# Altium schematic sheet Sheet1.SchDoc
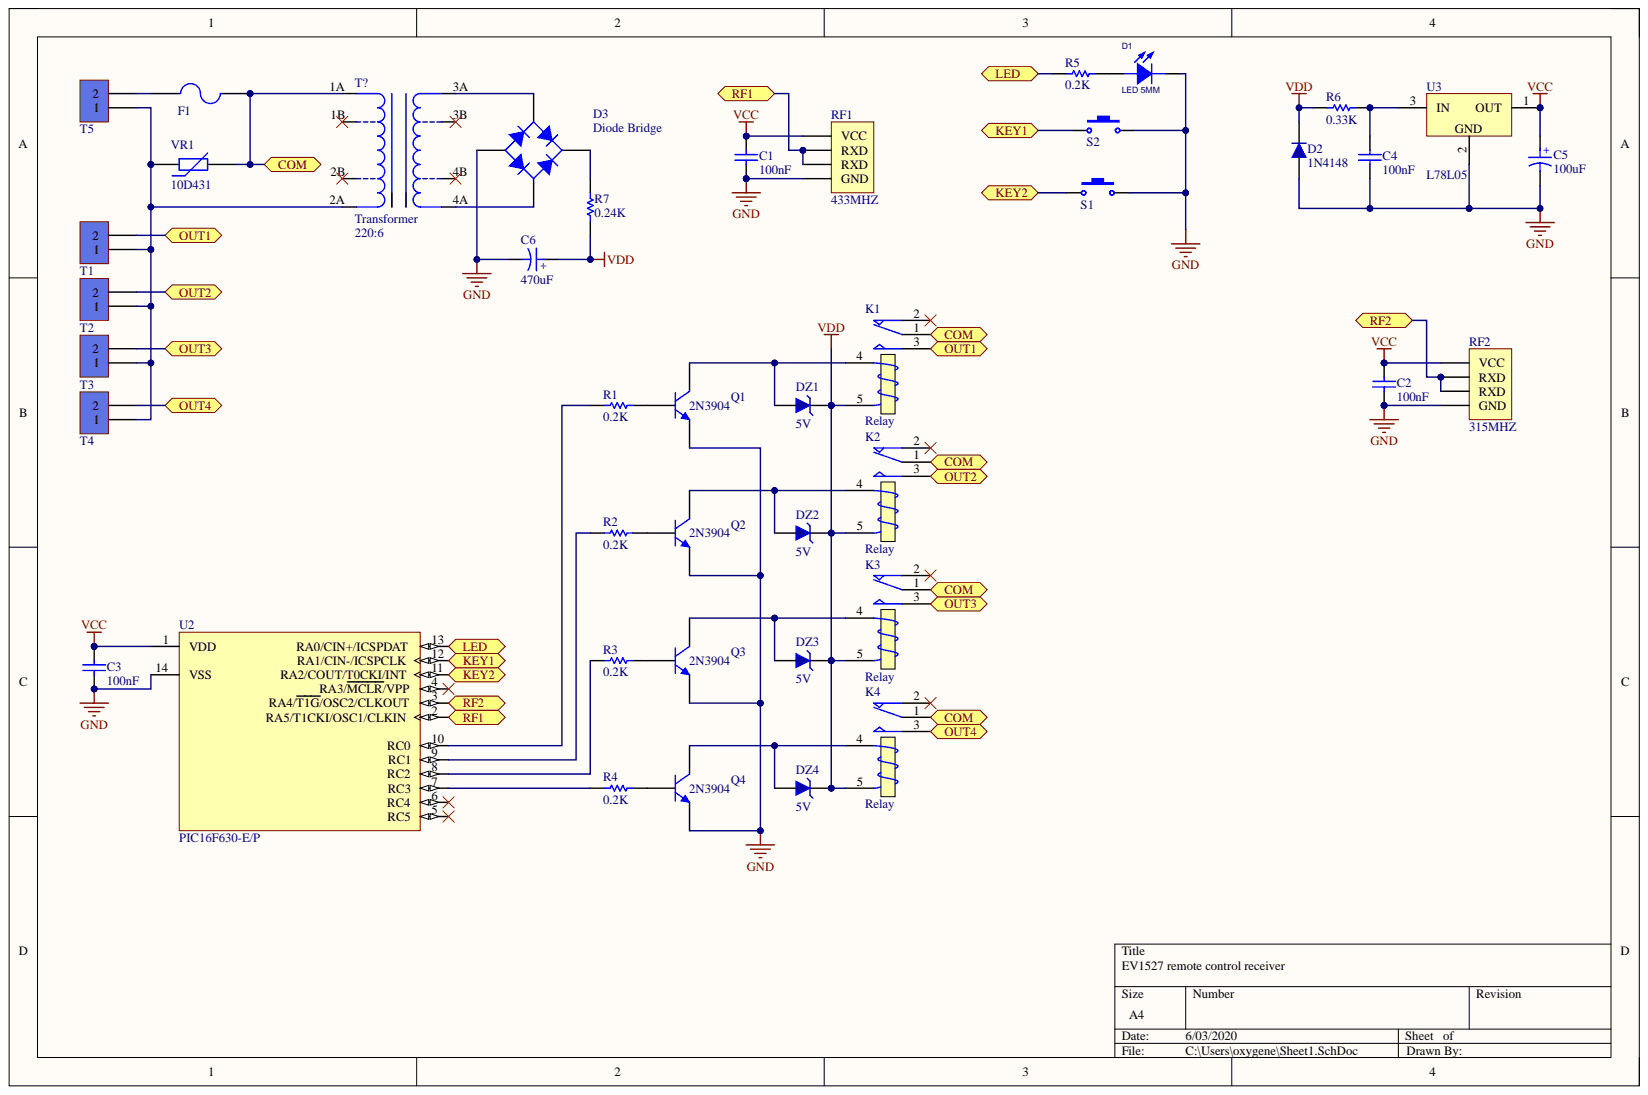
[diagram p0: Sheet1.SchDoc]

## Parts
C1  100nF  [Cap-100nF]
C2  100nF  [Cap-100nF]
C3  100nF  [Cap-100nF]
C4  100nF  [Cap-100nF]
C5  100uF  [Cap electrolitic radial 8x14-3.5]
C6  470uF  [Cap electrolitic radial 8x12-3.5]
D1  LED 5MM  [LED5MM_BLUE]
D2  1N4148  [DO 35]
D3  Diode Bridge  [Bridge WOB PACKAGE]
DZ1  5V  [DO 35]
DZ2  5V  [DO 35]
DZ3  5V  [DO 35]
DZ4  5V  [DO 35]
F1  F=1.0 A  [Fuse 5x20 With holder pin]  (value not drawn)
K1  Relay  [Relay 15.40x12.10x13.10]
K2  Relay  [Relay 15.40x12.10x13.10]
K3  Relay  [Relay 15.40x12.10x13.10]
K4  Relay  [Relay 15.40x12.10x13.10]
Q1  2N3904  [2N3904]
Q2  2N3904  [2N3904]
Q3  2N3904  [2N3904]
Q4  2N3904  [2N3904]
R1  0.2K  [RES-(1/4)]
R2  0.2K  [RES-(1/4)]
R3  0.2K  [RES-(1/4)]
R4  0.2K  [RES-(1/4)]
R5  0.2K  [RES-(1/4)]
R6  0.33K  [RES-(1/4)]
R7  0.24K  [RES-(1/4)-Stand]
RF1  433MHZ  [HDR1X4]
RF2  315MHZ  [HDR1X4]
S1  Switch PB  [Switch - Push to On]  (value not drawn)
S2  Switch PB  [Switch - Push to On]  (value not drawn)
T1  KF301_Terminal  [KF301 2PIN]  (value not drawn)
T2  KF301_Terminal  [KF301 2PIN]  (value not drawn)
T3  KF301_Terminal  [KF301 2PIN]  (value not drawn)
T4  KF301_Terminal  [KF301 2PIN]  (value not drawn)
T5  KF301_Terminal  [KF301 2PIN]  (value not drawn)
T?  Transformer  Voltage Ratio: 220:6  [Trans- Small]
U2  PIC16F630-E/P  [DIP14]
U3  L78L05  [78LXX]
VR1  10D431  [10D XXX]

## Ports
COM, KEY1, KEY2, LED, OUT1, OUT2, OUT3, OUT4, RF1, RF2

## Nets
GND: C1.1 C2.1 C3.1 C4.1 C5.2 C6.2 D1.2 D2.1 D3.4 Q1.1 Q2.1 Q3.1 Q4.1 RF1.4 RF2.4 S1.2 S2.2 U2.14 U3.2
VCC: C1.2 C2.2 C3.2 C5.1 RF1.1 RF2.1 U2.1 U3.1
VDD: C6.1 D2.2 DZ1.2 DZ2.2 DZ3.2 DZ4.2 K1.5 K2.5 K3.5 K4.5 R6.2 R7.1
COM: F1.2 K1.1 K2.1 K3.1 K4.1 T?.1A VR1.2 ; port COM
KEY1: S2.1 U2.12 ; port KEY1
KEY2: S1.1 U2.11 ; port KEY2
LED: R5.1 U2.13 ; port LED
OUT1: K1.3 T1.2 ; port OUT1
OUT2: K2.3 T2.2 ; port OUT2
OUT3: K3.3 T3.2 ; port OUT3
OUT4: K4.3 T4.2 ; port OUT4
RF1: RF1.2 RF1.3 U2.2 ; port RF1
RF2: RF2.2 RF2.3 U2.3 ; port RF2
NetC4_2: C4.2 R6.1 U3.3
NetD1_1: D1.1 R5.2
NetD3_1: D3.1 R7.2
NetD3_2: D3.2 T?.3A
NetD3_3: D3.3 T?.4A
NetDZ1_1: DZ1.1 K1.4 Q1.3
NetDZ2_1: DZ2.1 K2.4 Q2.3
NetDZ3_1: DZ3.1 K3.4 Q3.3
NetDZ4_1: DZ4.1 K4.4 Q4.3
NetF1_1: F1.1 T5.2
NetQ1_2: Q1.2 R1.2
NetQ2_2: Q2.2 R2.2
NetQ3_2: Q3.2 R3.2
NetQ4_2: Q4.2 R4.2
NetR1_1: R1.1 U2.10
NetR2_1: R2.1 U2.9
NetR3_1: R3.1 U2.8
NetR4_1: R4.1 U2.7
NetT1_1: T1.1 T2.1 T3.1 T4.1 T5.1 T?.2A VR1.1

Not connected: K1 2; K2 2; K3 2; K4 2; T? 1B 2B 3B 4B SA SB; U2 4 5 6

## Library data of the parts
C1-C4 = CAP CERAMIC TOTAL SIZE: CAP Ceramic Total; Designer: Ali Hafizi; Component Kind: Standard; Library Name: Altium.IntLib
C5 C6 = Cap ELECTROLITIC TOTAL SIZE: Cap electrolitic radial; Designer: Abbas Basereh; Component Kind: Standard; Data: 16-12-2016; Library Name: Altium.IntLib
  C5: VALUE: 100uF
  C6: VALUE: 470uF
D1 = LED 5MM: Light Emitting Diode; Designer: Abbas Basereh; Component Kind: Standard; Data: 16-12-2016; Library Name: Altium.IntLib
D2 = 1N4148: DIODE GEN PURP 100V 200MA DO35; Component Kind: Standard; ComponentLink1Description: Manufacturer Link; ComponentLink1URL: http://www.digikey.com/product-detail/en/fairchild-on-semiconductor/1N4148/1N4148FS-ND/458603; ComponentLink2Description: Datasheet; Library Name: Altium.IntLib; PackageDescription: DIODE GEN PURP 100V 200MA DO35; PackageReference: DO 35; PackageVersion: 2017-1-12; PART.NO: 1N4148; Published: 2017-1-12; Publisher: Abbas Basereh
D3 = Diode Bridge TOTAL SIZE: Full Wave Diode Bridge; Designer: Abbas Basereh; Component Kind: Standard; ComponentLink1Description: Datasheet; ComponentLink2Description: Reference; Design Date: 1395/11/09; Library Name: Altium.IntLib; Mounting Technology: Surface Mount\Through-Hole; Status: Unreleased
DZ1-DZ4 = ZENER GENERAL DIODE: DIODE ZENER GENERAL; Component Kind: Standard; ComponentLink1Description: Manufacturer Link; ComponentLink2Description: Datasheet; Library Name: Altium.IntLib; PackageDescription: DIODE GEN ZENER; PackageReference: DO 35; PackageVersion: 2017-1-12; Published: 2017-1-12; VALUE: 5V; Publisher: Abbas Basereh
F1 = Fuse 5x20 with holdeer pins: Fuses 5x20; Desc.: fuse with holder pins 5x20; Designer: Abbas Basereh; Component Kind: Standard; Data: 28-12-2016; Library Name: Altium.IntLib
K1-K4 = RELAY General: Relay_SPDT POWER NEEDLE; Company: E.P.I.L; Designer: Ali Hafizi; Component Kind: Standard; Design_Date: 2011/10/31; Library Name: Altium.IntLib
Q1-Q4 = 2N3904: NPN Transistor; Component Kind: Standard; Library Name: Altium.IntLib
R1-R7 = RES DIP PACKAGE: TOTAL DIP RESISTOR PACK; Designer: Ali Hafizi; Component Kind: Standard; Library Name: Altium.IntLib
RF1 RF2 = Header 4: RF Receiver; Component Kind: Standard; LatestRevisionDate: 17-Jul-2002; LatestRevisionNote: Re-released for DXP Platform.; Library Name: Altium.IntLib; Signal Integrity: Connector; Publisher: Altium Limited
S1 S2 = Switch PB: Push Button Switch; Component Kind: Standard; Library Name: Altium.IntLib
T1-T5 = KF301-2Pin_Terminal: Terminal 2 Pin 250VAC 16A; Part. No: KF301 2P; Designer: Abbas Basereh; Component Kind: Standard; Library Name: Altium.IntLib; Link: https://www.aliexpress.com/item/
T? = Transformer: Transformer 4 Primary , 4 Secondry; Company: DASE CO; Power: 100W; Adding Date: 10/27/2010; Component Kind: Standard; Library Name: Altium.IntLib
U2 = PIC16F630-E/P: FLASH-Based 8-Bit CMOS Microcontroller, 1K (x14-Bit words) FLASH, 64 Bytes SRAM, 14-Pin PDIP, 2.0 to 5.5V Supply Range, Extended Temperature; Manufacturer: Microchip; Component Kind: Standard; ComponentLink1Description: Manufacturer URL; ComponentLink1URL: http://www.microchip.com/; ComponentLink2Description: Datasheet; ComponentLink2URL: http://ww1.microchip.com/downloads/en/DeviceDoc/40039E.pdf; ComponentLink3Description: Package Specification; ComponentLink3URL: http://www.microchip.com/stellent/groups/techpub_sg/documents/packagingspec/en012702.pdf; DatasheetVersion: revE, Mar-2007; Library Name: Microchip PIC16 Part 2.IntLib; PackageDescription: 14-Lead Plastic Dual In-Line (P) - 300 mil Body [PDIP]; PackageReference: PDIP300-P14; PackageVersion: revBB, Aug-2009; PartNumber: PIC16F630-E/P; Supplier 1: Digi-Key; Supplier 2: Newark; Supplier 3: Mouser; Supplier Part Number 1: PIC16F630-E/P-ND; Supplier Part Number 2: 92C4542; Supplier Part Number 3: 579-PIC16F630-E/P
U3 = L78LXX: Fixed Voltage Low Power Regulator; Company: ControTec; Designer: Saeid Jorablo; Adding Date: 1387/01/06; Component Kind: Standard; Library Name: Altium.IntLib
VR1 = VARISTOR (VDR): VARISTORS; Manufacturer: china-canada-philipina; Designer: Abbas Basereh; Models: MOV-VDR-COV; Component Kind: Standard; Data: 2017-1-5; Library Name: Altium.IntLib; Link: digikey.com; VALUE: 10D431; PUBLISHER: ABBAS BASEREH
